# Altium schematic sheet SCH006 - VSC742x Uplink 1GbpE magjack, RS232.SchDoc
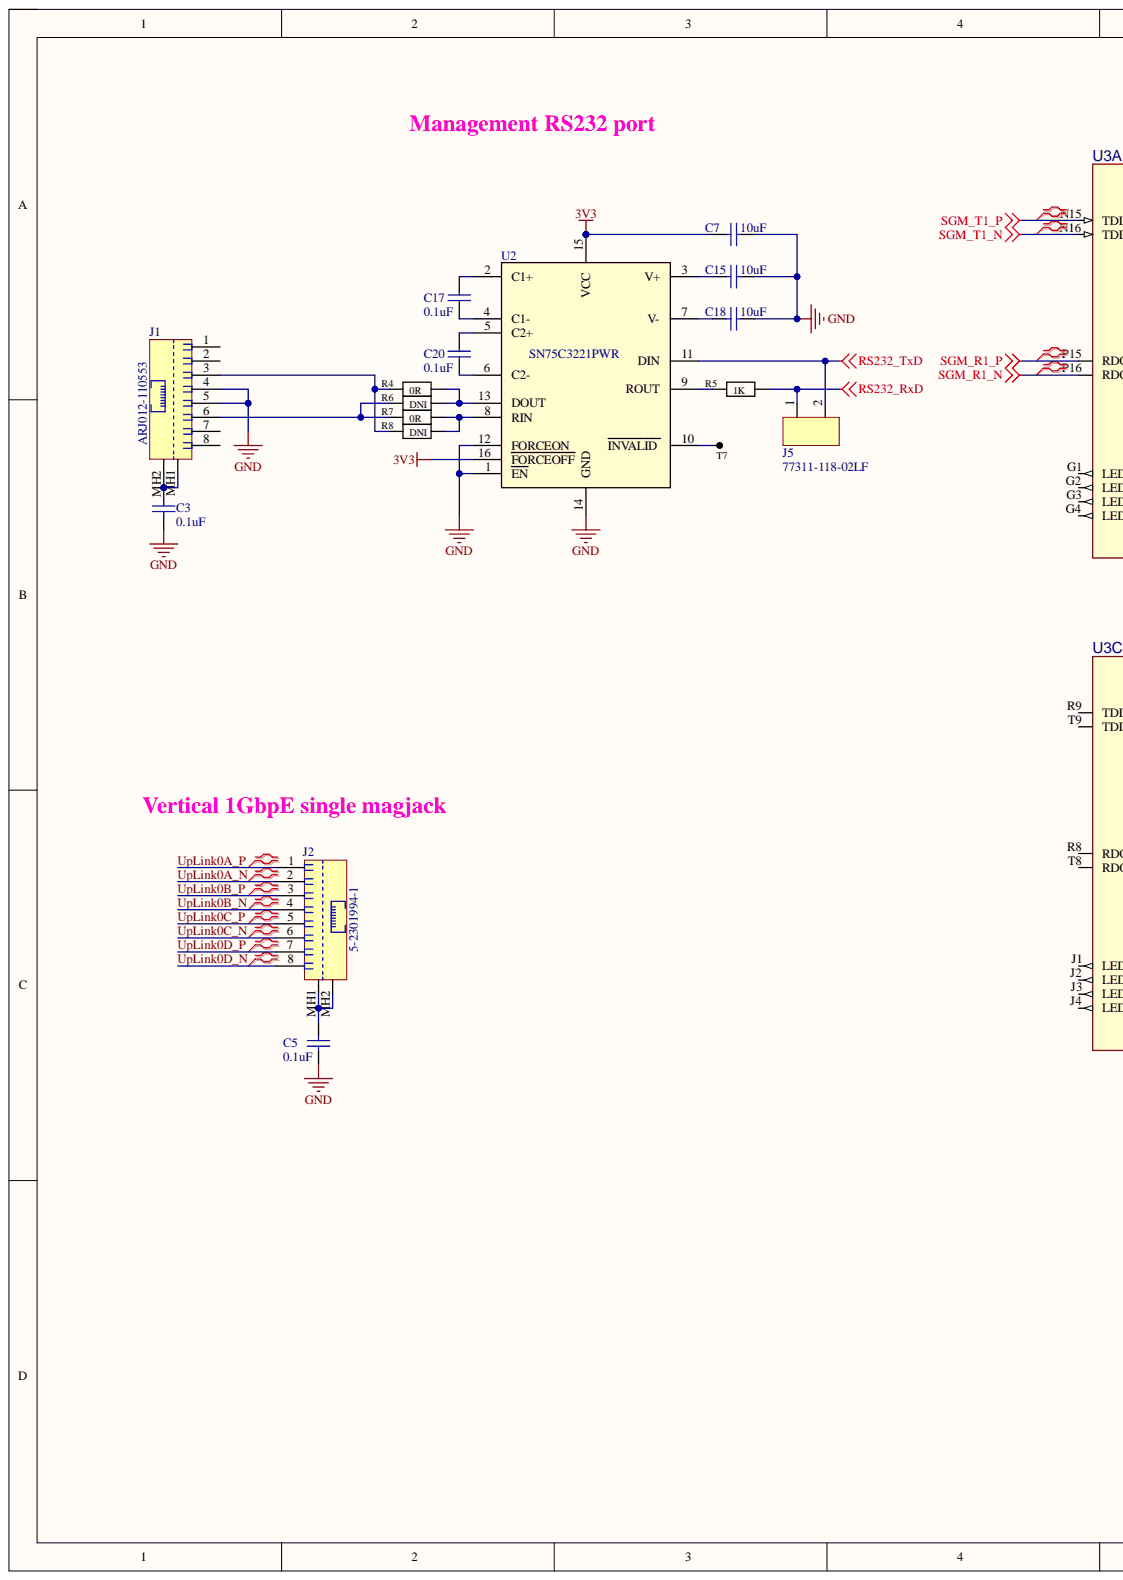
[diagram p0: SCH006 - VSC742x Uplink 1GbpE magjack, RS232.SchDoc, left]
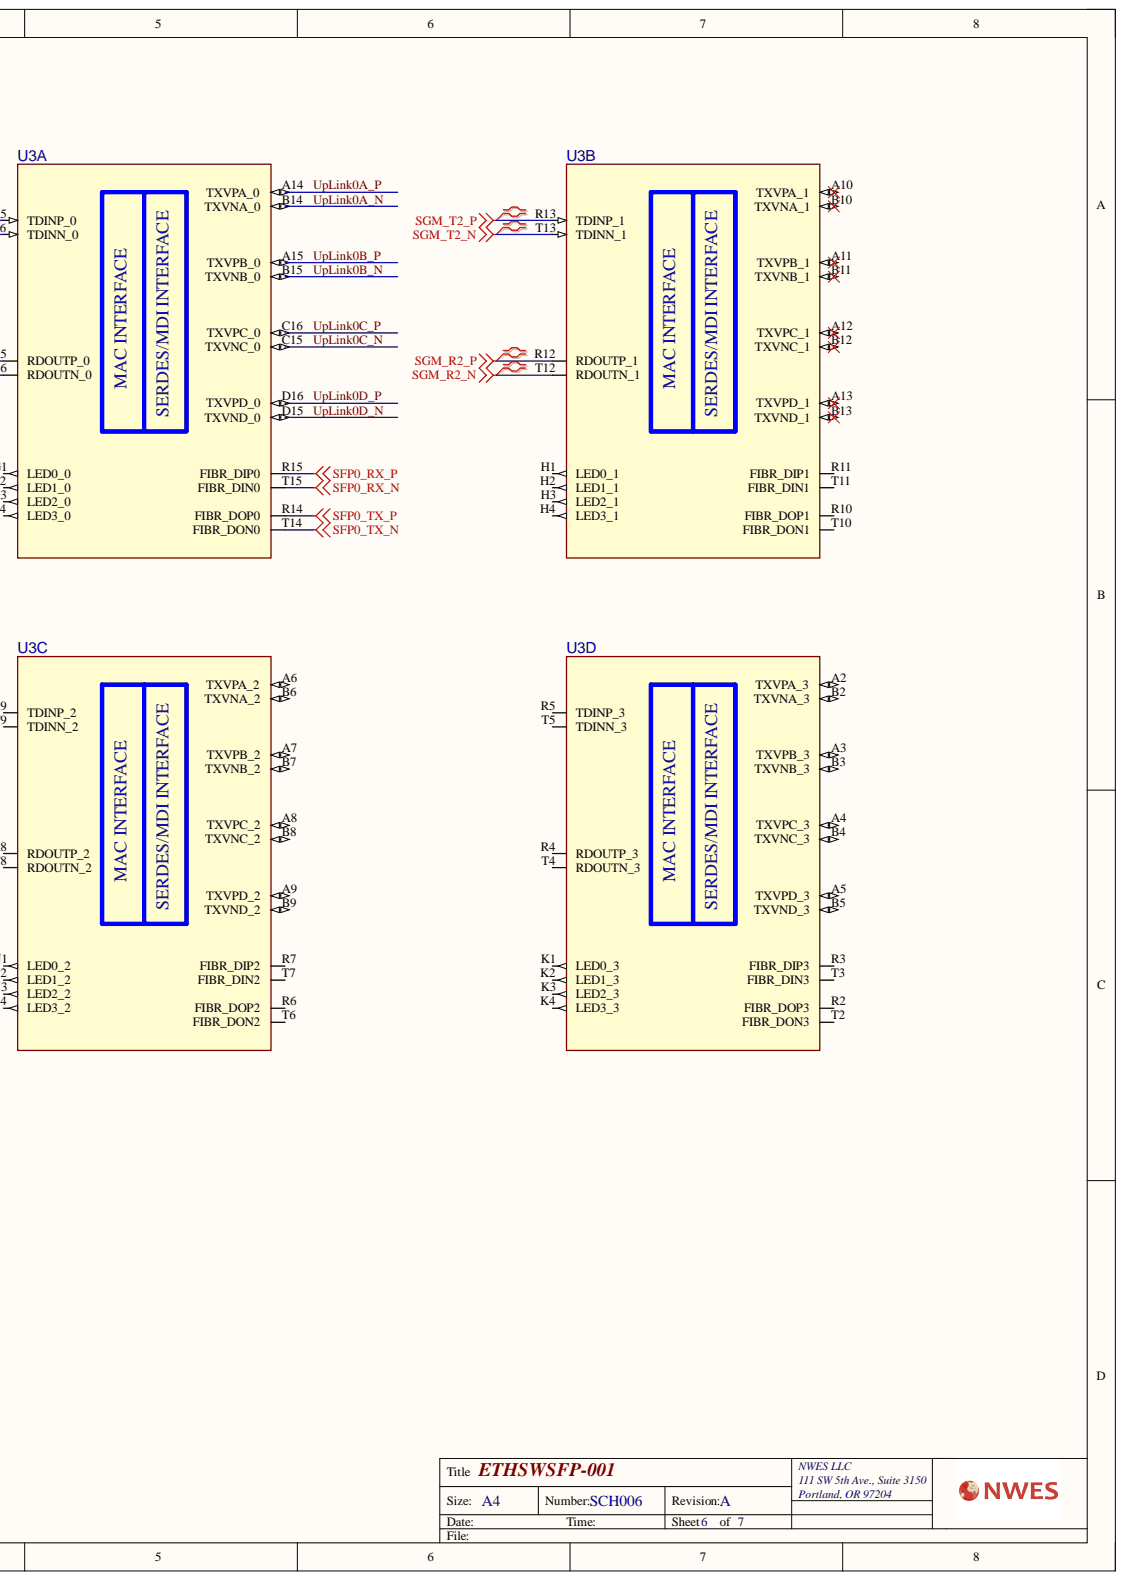
[diagram p1: SCH006 - VSC742x Uplink 1GbpE magjack, RS232.SchDoc, right]

## Parts
C3  0.1uF  [CAPC1005X61USR]
C5  0.1uF  [CAPC1005X61USR]
C7  10uF  [CAPC1005X61USR]
C15  10uF  [CAPC1005X61USR]
C17  0.1uF  [CAPC1005X61USR]
C18  10uF  [CAPC1005X61USR]
C20  0.1uF  [CAPC1005X61USR]
J1  ARJ012-110553  [ARJ012-110553]
J2  5-2301994-1  [5-2301994-1]
J5  77311-118-02LF  [HDR1X2]
R4  0R  [RESC1005X40USR]
R5  1K  [RESC1005X40USR]
R6  DNI  value 0R  [RESC1005X40USR]
R7  0R  [RESC1005X40USR]
R8  DNI  value 0R  [RESC1005X40USR]
T7  TP_S  [TP 1mm]  (value not drawn)  (no BOM)
U2  SN75C3221PWR  [PW16]
U3  VSC8574XKS-01  [256-BGA_1700X1700]  (value not drawn)  (parts A,B,C,D)

## Nets
3V3: C7.1 U2.15(VCC) U2.16(FORCEOFF)
GND: C3.2 C5.2 C7.2 C15.2 C18.2 J1.4 J1.5 U2.1(EN) U2.12(FORCEON) U2.14(GND)
RS232_RxD: J5.1 R5.1
RS232_TxD: J5.2 U2.11(DIN)
SFP0_RX_N: U3.T15(FIBR_DIN0)
SFP0_RX_P: U3.R15(FIBR_DIP0)
SFP0_TX_N: U3.T14(FIBR_DON0)
SFP0_TX_P: U3.R14(FIBR_DOP0)
SGM_R1_N: U3.P16(RDOUTN_0)
SGM_R1_P: U3.P15(RDOUTP_0)
SGM_R2_N: U3.T12(RDOUTN_1)
SGM_R2_P: U3.R12(RDOUTP_1)
SGM_T1_N: U3.N16(TDINN_0)
SGM_T1_P: U3.N15(TDINP_0)
SGM_T2_N: U3.T13(TDINN_1)
SGM_T2_P: U3.R13(TDINP_1)
UpLink0A_N: J2.2 U3.B14(TXVNA_0)
UpLink0A_P: J2.1 U3.A14(TXVPA_0)
UpLink0B_N: J2.4 U3.B15(TXVNB_0)
UpLink0B_P: J2.3 U3.A15(TXVPB_0)
UpLink0C_N: J2.6 U3.C15(TXVNC_0)
UpLink0C_P: J2.5 U3.C16(TXVPC_0)
UpLink0D_N: J2.8 U3.D15(TXVND_0)
UpLink0D_P: J2.7 U3.D16(TXVPD_0)
NetC3_1: C3.1 J1.MH1 J1.MH2
NetC5_1: C5.1 J2.MH1 J2.MH2
NetC15_1: C15.1 U2.3(V+)
NetC17_1: C17.1 U2.4(C1-)
NetC17_2: C17.2 U2.2(C1+)
NetC18_1: C18.1 U2.7(V-)
NetC20_1: C20.1 U2.6(C2-)
NetC20_2: C20.2 U2.5(C2+)
NetJ1_3: J1.3 R4.2 R8.2
NetJ1_6: J1.6 R6.2 R7.2
NetR4_1: R4.1 R6.1 U2.13(DOUT)
NetR5_2: R5.2 U2.9(ROUT)
NetR7_1: R7.1 R8.1 U2.8(RIN)
NetT7_1: T7.1 U2.10(INVALID)

Not connected: J1 1 2 7 8; U3 A2(TXVPA_3) A3(TXVPB_3) A4(TXVPC_3) A5(TXVPD_3) A6(TXVPA_2) A7(TXVPB_2) A8(TXVPC_2) A9(TXVPD_2) A10(TXVPA_1) A11(TXVPB_1) A12(TXVPC_1) A13(TXVPD_1) B2(TXVNA_3) B3(TXVNB_3) B4(TXVNC_3) B5(TXVND_3) B6(TXVNA_2) B7(TXVNB_2) B8(TXVNC_2) B9(TXVND_2) B10(TXVNA_1) B11(TXVNB_1) B12(TXVNC_1) B13(TXVND_1) G1(LED0_0) G2(LED1_0) G3(LED2_0) G4(LED3_0) H1(LED0_1) H2(LED1_1) H3(LED2_1) H4(LED3_1) J1(LED0_2) J2(LED1_2) J3(LED2_2) J4(LED3_2) K1(LED0_3) K2(LED1_3) K3(LED2_3) K4(LED3_3) R2(FIBR_DOP3) R3(FIBR_DIP3) R4(RDOUTP_3) R5(TDINP_3) R6(FIBR_DOP2) R7(FIBR_DIP2) R8(RDOUTP_2) R9(TDINP_2) R10(FIBR_DOP1) R11(FIBR_DIP1) T2(FIBR_DON3) T3(FIBR_DIN3) T4(RDOUTN_3) T5(TDINN_3) T6(FIBR_DON2) T7(FIBR_DIN2) T8(RDOUTN_2) T9(TDINN_2) T10(FIBR_DON1) T11(FIBR_DIN1)

## Library data of the parts
C3 C5 C17 C20 = CAP CER 0.1UF 50V X7R 0402: Manufacturer: Murata Electronics; Manufacturer Part Number: GRM155R71H104KE14D; Component Kind: Standard; Description: CAP CER 0.1UF 50V X7R 0402; Package: 0402; Supplier 1: Digi-Key; Supplier Part Number 1: 490-10700-2-ND; value: 0.1uF; Library Name: 15-port switch V1.SCHLIB
C7 C15 C18 = CAP CER 10UF 10V X5R 0402: Manufacturer: Samsung Electro-Mechanics; Manufacturer Part Number: CL05A106MP8NUB8; Component Kind: Standard; Description: CAP CER 10UF 10V X5R 0402; Package: 0402; Supplier 1: Digi-Key; Supplier Part Number 1: 1276-6830-1-ND; value: 10uF; Library Name: 15-port switch V1.SCHLIB
J1 = ARJ012-110553: Conn RJ-45 RCP 8 POS 1.27mm Solder RA Thru-Hole 8 Terminal 1 Port Tray; Manufacturer: Abracon; Category: Modular / Ethernet Connectors; Component Kind: Standard; ComponentLink1Description: https://abracon.com/Magnetics/Pure-RJ45/ARJ012-110553.PDF?src-supplier=Digikey; ComponentLink1URL: https://abracon.com/Magnetics/Pure-RJ45/ARJ012-110553.PDF?src-supplier=Digikey; Library Name: ALT_NA_LIB.SchLib; Manufacturer Part Number: ARJ012-110553; Pricing: 1260=1.96243 (USD); RoHS: Yes; Supplier: Digikey; Supplier Part Number: 535-13279-ND
J2 = 5-2301994-1: RJ45 Connector, Jack, 8P8C, 1PORT, Th; Manufacturer: TE Connectivity; Category: Modular / Ethernet Connectors; Component Kind: Standard; ComponentLink1Description: https://datasheet.octopart.com/5-2301994-1-TE-Connectivity-datasheet-156008867.pdf?src-supplier=Future+Electronics; ComponentLink1URL: https://datasheet.octopart.com/5-2301994-1-TE-Connectivity-datasheet-156008867.pdf?src-supplier=Future+Electronics; ComponentLink2Description: https://www.te.com/commerce/DocumentDelivery/DDEController?Action=srchrtrv&amp;DocNm=5-2301994-1&amp;DocType=Customer+Drawing&amp;DocLang=English&src-supplier=Digikey; ComponentLink2URL: https://www.te.com/commerce/DocumentDelivery/DDEController?Action=srchrtrv&amp;DocNm=5-2301994-1&amp;DocType=Customer+Drawing&amp;DocLang=English&src-supplier=Digikey; Library Name: ALT_NA_LIB.SchLib; Manufacturer Part Number: 5-2301994-1; Pricing: 1=5.88, 10=5.151, 25=5.0156, 50=4.908, 100=4.6113, 250=4.58432, 950=4.28767, 2400=3.82924 (USD); RoHS: Yes; Stock: 1819; Supplier: Digikey; Supplier Part Number: A126250-ND
J5 = HDR1X2: Conn Unshrouded Header HDR 2 POS 2.54mm Solder ST Top Entry Thru-Hole BergStik® Bulk; Manufacturer: Amphenol ICC / FCI; Category: Headers and Wire Housings; Component Kind: Standard; ComponentLink1Description: https://datasheet.octopart.com/77311-118-02LF-FCI-datasheet-41338623.pdf?src-supplier=Newark; ComponentLink1URL: https://datasheet.octopart.com/77311-118-02LF-FCI-datasheet-41338623.pdf?src-supplier=Newark; ComponentLink2Description: https://datasheet.octopart.com/77311-118-02LF-Amphenol-Communications-Solutions-datasheet-106547766.pdf?src-supplier=Future+Electronics; ComponentLink2URL: https://datasheet.octopart.com/77311-118-02LF-Amphenol-Communications-Solutions-datasheet-106547766.pdf?src-supplier=Future+Electronics; ComponentLink3Description: https://datasheet.octopart.com/77311-118-02LF-Areva-datasheet-118559.pdf?src-supplier=Digikey; ComponentLink3URL: https://datasheet.octopart.com/77311-118-02LF-Areva-datasheet-118559.pdf?src-supplier=Digikey; ComponentLink4Description: https://datasheet.octopart.com/77311-118-02LF-Amphenol-datasheet-94059547.pdf?src-supplier=Farnell; ComponentLink4URL: https://datasheet.octopart.com/77311-118-02LF-Amphenol-datasheet-94059547.pdf?src-supplier=Farnell; ComponentLink5Description: https://datasheet.octopart.com/77311-118-02LF-Areva-datasheet-5394197.pdf?src-supplier=Element14; ComponentLink5URL: https://datasheet.octopart.com/77311-118-02LF-Areva-datasheet-5394197.pdf?src-supplier=Element14; ComponentLink6Description: https://datasheet.octopart.com/77311-118-02LF-Amphenol-Communications-Solutions-datasheet-168215135.pdf?src-supplier=TME; ComponentLink6URL: https://datasheet.octopart.com/77311-118-02LF-Amphenol-Communications-Solutions-datasheet-168215135.pdf?src-supplier=TME; ComponentLink7Description: https://datasheet.octopart.com/77311-118-02LF-Amphenol-Communications-Solutions-datasheet-154727348.pdf?src-supplier=Electro+Sonic; ComponentLink7URL: https://datasheet.octopart.com/77311-118-02LF-Amphenol-Communications-Solutions-datasheet-154727348.pdf?src-supplier=Electro+Sonic; Library Name: ALT_NA_LIB.SchLib; Manufacturer Part Number: 77311-118-02LF; Pricing: 1=0.21, 10=0.176, 100=0.1353, 500=0.1145, 1000=0.09368, 5000=0.08327, 10000=0.07547 (USD); RoHS: No; Stock: 33378; Supplier: Digikey; Supplier Part Number: 609-4434-ND
R4 R7 = RES 0 OHM JUMPER 1/16W 0402: Manufacturer: Yageo; Manufacturer Part Number: RC0402FR-070RL; Component Kind: Standard; Description: RES 0 OHM JUMPER 1/16W 0402; Height: 0.40mm; Package: 0402; Supplier 1: Digi-Key; Supplier Part Number 1: 311-0.0LRCT-ND; Value: 0R; Library Name: 15-port switch V1.SCHLIB
R5 = RES SMD 1K OHM 1% 1/16W 0402: Manufacturer: Yageo; Manufacturer Part Number: RC0402FR-071KP; Component Kind: Standard; Description: RES SMD 1K OHM 1% 1/16W 0402; Height: 0.40mm; Package: 0402; Supplier 1: Digi-Key; Supplier Part Number 1: YAG1254CT-ND; Value: 1K; Library Name: 15-port switch V1.SCHLIB
R6 R8 = RES DNI: RES 0 OHM JUMPER 1/16W 0402; Manufacturer: Yageo; Manufacturer Part Number: RC0402FR-070RL; Component Kind: Standard; Height: 0.40mm; Package: 0402; Supplier 1: Digi-Key; Supplier Part Number 1: 311-0.0LRCT-ND; Library Name: 15-port switch V1.SCHLIB
T7 = TP_S: test point; Library Name: 26-port switch.SCHLIB
U2 = MAX3221EIPWR: IC TRANSCEIVER FULL 1/1 16TSSOP; Manufacturer: Texas Instruments; FAMILY_NAME: RS-232; Component Kind: Standard; Manufacturer Part Number: SN75C3221PWR; Supplier 1: Digi-Key; Supplier Part Number 1: 296-13323-1-ND; Library Name: 15-port switch V1.SCHLIB
U3 = VSC8574: IC TELECOM INTERFACE QUAD; Manufacturer: Microchip Technology; Component Kind: Standard; Manufacturer Part Number: VSC8574XKS-01; Supplier 1: Mouser; Supplier Part Number 1: 494-VSC8574XKS-01; Library Name: 15-port switch V1.SCHLIB
